# Altium schematic sheet robotShield_Buttom.SchDoc
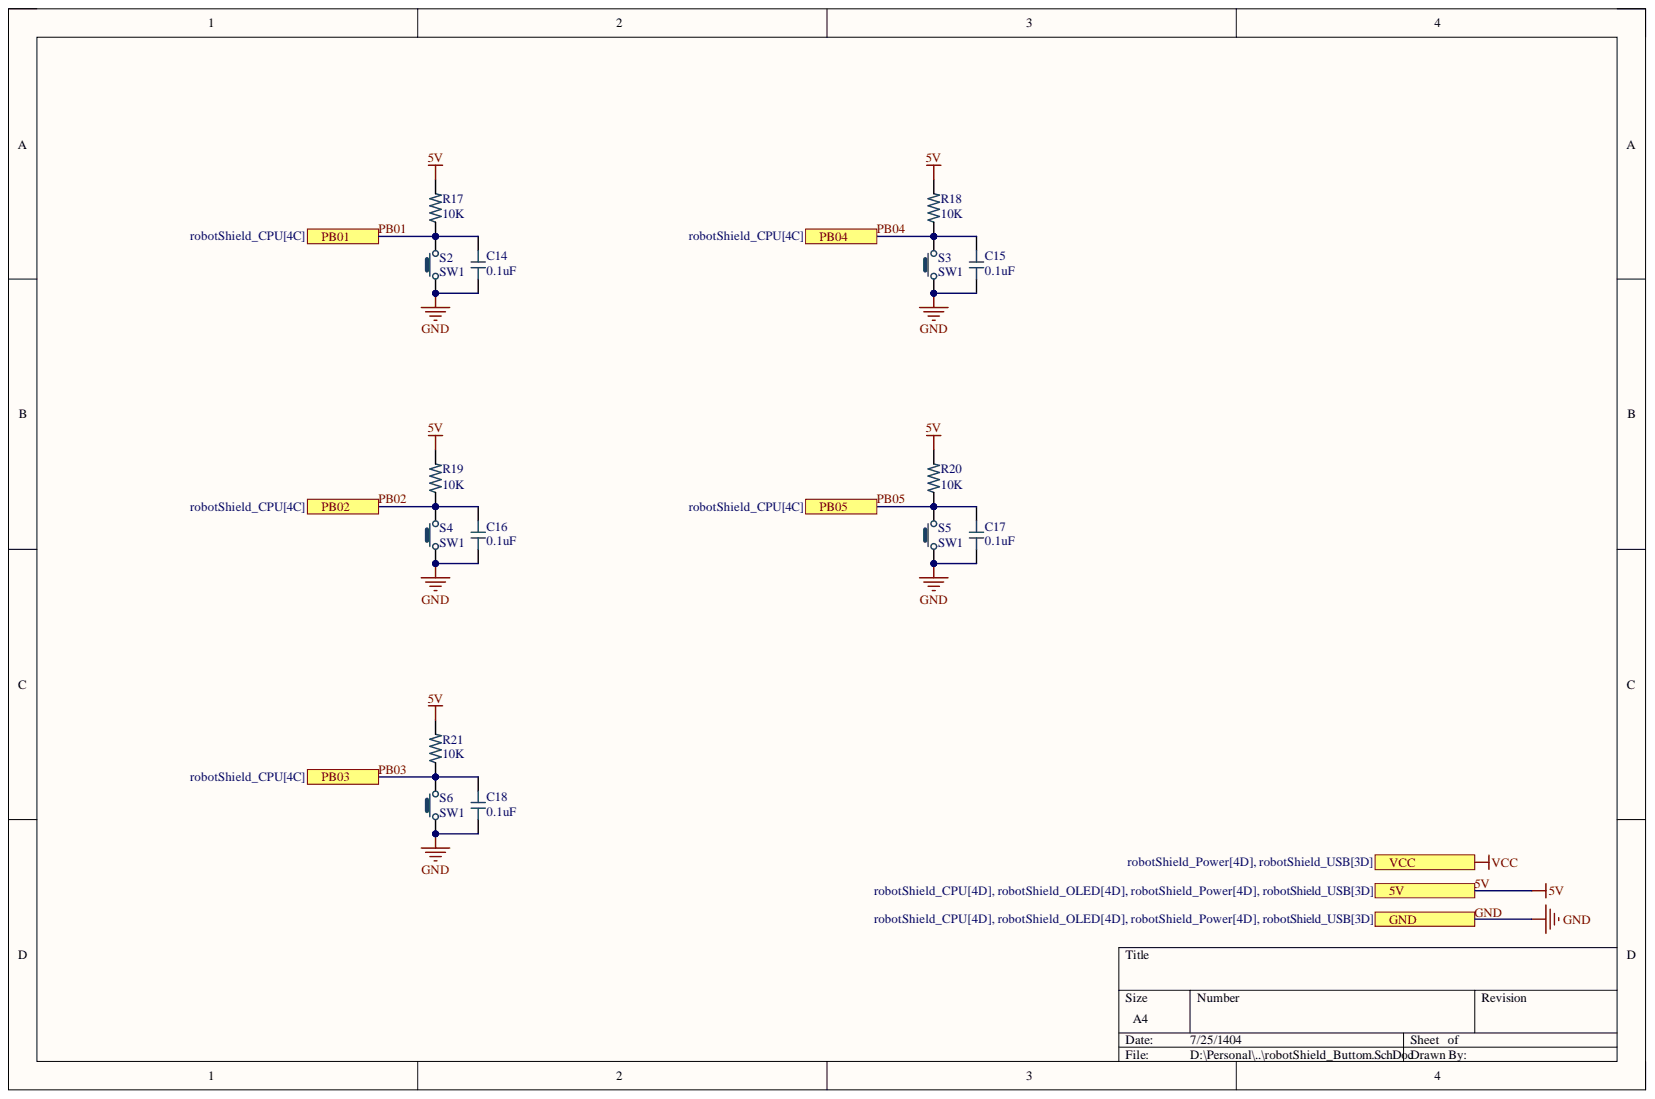
[diagram p0: robotShield_Buttom.SchDoc]

## Parts
C14  0.1uF  [CAP0603]
C15  0.1uF  [CAP0603]
C16  0.1uF  [CAP0603]
C17  0.1uF  [CAP0603]
C18  0.1uF  [CAP0603]
R17  10K  [RES0603]
R18  10K  [RES0603]
R19  10K  [RES0603]
R20  10K  [RES0603]
R21  10K  [RES0603]
S2  SW1  [Pushbutton_Tactile]
S3  SW1  [Pushbutton_Tactile]
S4  SW1  [Pushbutton_Tactile]
S5  SW1  [Pushbutton_Tactile]
S6  SW1  [Pushbutton_Tactile]

## Ports
5V, GND, PB01, PB02, PB03, PB04, PB05, VCC

## Nets
5V: R17.2 R18.2 R19.2 R20.2 R21.2 ; port 5V
GND: C14.2 C15.2 C16.2 C17.2 C18.2 S2.1 S3.1 S4.1 S5.1 S6.1 ; port GND
PB01: C14.1 R17.1 S2.2 ; port PB01
PB02: C16.1 R19.1 S4.2 ; port PB02
PB03: C18.1 R21.1 S6.2 ; port PB03
PB04: C15.1 R18.1 S3.2 ; port PB04
PB05: C17.1 R20.1 S5.2 ; port PB05
VCC: ; port VCC

## Library data of the parts
C14-C18 = Capacitor: CAP SMD; MF: AVX; MP: LD031A101GAB2A; Availability: Unavailable; Component Kind: Standard; Package: 0603 AVX; Price: None; SnapEDA_Link: https://www.snapeda.com/parts/LD031A101GAB2A/AVX/view-part/1973966/?ref=snap; Library Name: Hossein72T.IntLib
R17-R21 = Resistor: RES 0.27 OHM 1% 1/10W; MF: TE Connectivity; MP: 3-1879460-5; Power_Rating: .1 W; Resistance: 27; Availability: In Stock; Check_prices: https://www.snapeda.com/parts/3-1879460-5/TE+Connectivity/view-part/?ref=eda; Component Kind: Standard; EU_RoHS_Compliance: Compliant with Exemptions; Package: 0603; Price: None; SnapEDA_Link: https://www.snapeda.com/parts/3-1879460-5/TE+Connectivity/view-part/?ref=snap; Tolerance: 1%; Library Name: Hossein72T.IntLib
S2-S6 = Pushbutton: Switch,Pushbutton,Tactile,SPST; Component Kind: Standard; Library Name: Hossein72T.IntLib
